# Altium schematic sheet Top.SchDoc(Top)
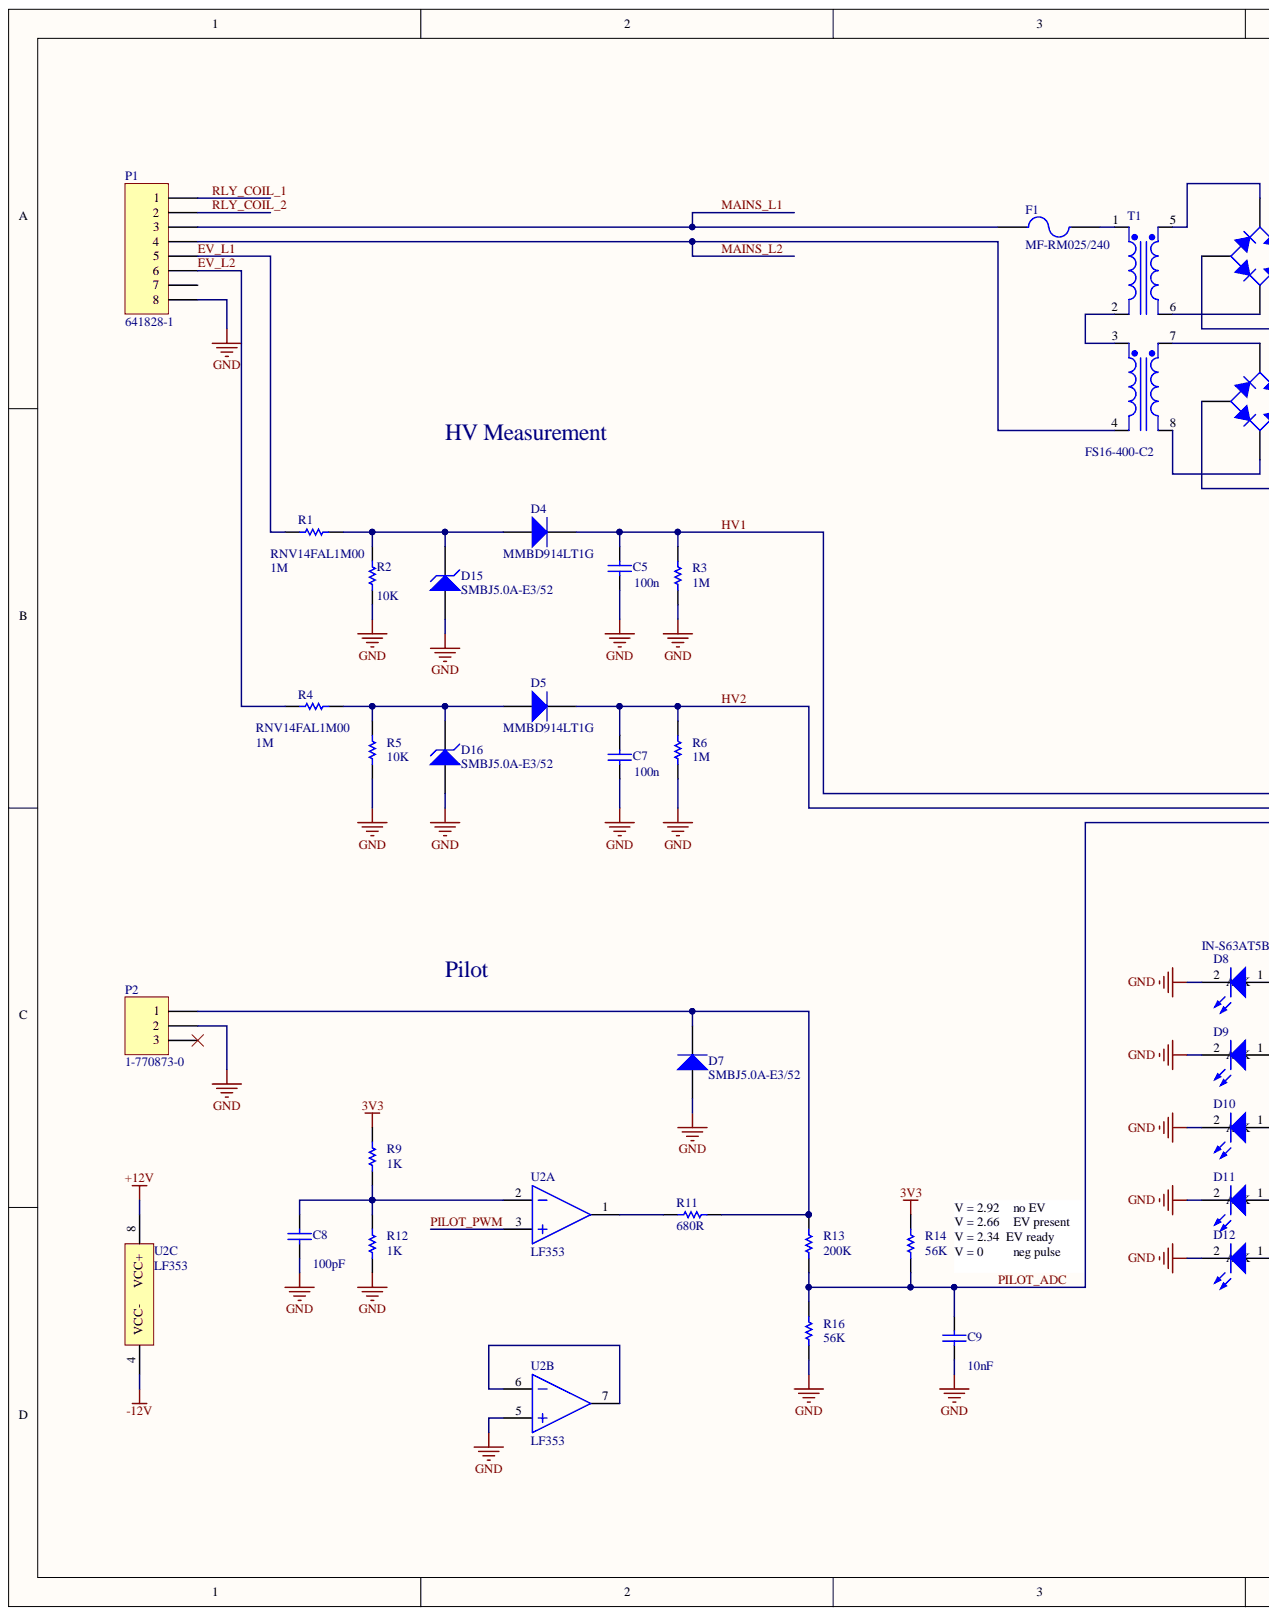
[diagram p0: Top.SchDoc, left]
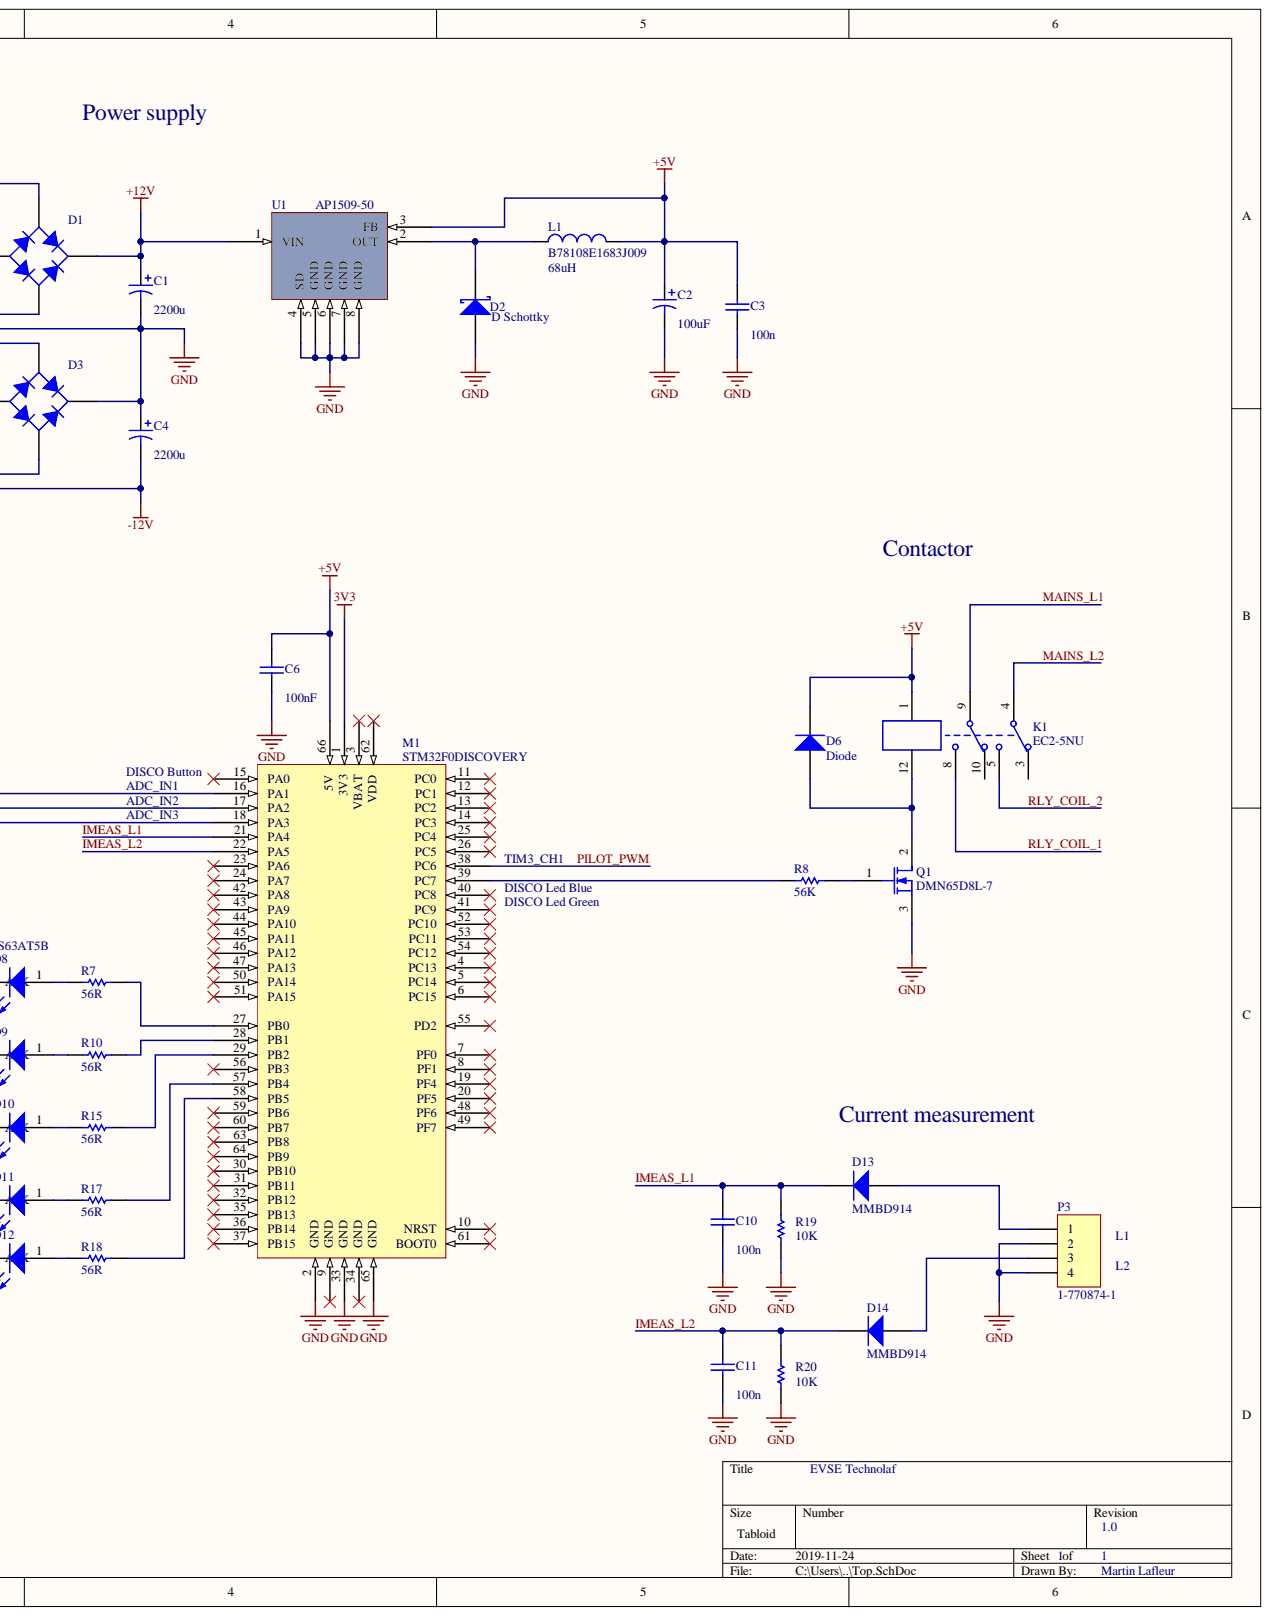
[diagram p1: Top.SchDoc, right]

## Parts
C1  value 2200u  [RB7.6-15]  (hidden comment: Cap Pol1)
C2  value 100uF  [RB0.1]  (hidden comment: Cap Pol1)
C3  value 100n  [6-0805_M]  (hidden comment: Cap)
C4  2200u  [RB7.6-15]
C5  value 100n  [6-0805_M]  (hidden comment: Cap)
C6  value 100nF  [6-0805_M]  (hidden comment: Cap)
C7  value 100n  [6-0805_M]  (hidden comment: Cap)
C8  value 100pF  [6-0805_M]  (hidden comment: Cap)
C9  value 10nF  [6-0805_M]  (hidden comment: Cap)
C10  value 100n  [6-0805_M]  (hidden comment: Cap)
C11  value 100n  [6-0805_M]  (hidden comment: Cap)
D1  Bridge1  [KBP06M]  (value not drawn)
D2  D Schottky  [AXIAL-0.6]
D3  Bridge1  [KBP06M]  (value not drawn)
D4  MMBD914LT1G  [SOT23_914]
D5  MMBD914LT1G  [SOT23_914]
D6  Diode  [SOT23_914]
D7  SMBJ5.0A-E3/52  [SMB]
D8  IN-S63AT5B  [LED_T13/4]
D9  (no value)  [LED_T13/4]
D10  (no value)  [LED_T13/4]
D11  (no value)  [LED_T13/4]
D12  (no value)  [LED_T13/4]
D13  MMBD914  [SOT23_914]
D14  MMBD914  [SOT23_914]
D15  SMBJ5.0A-E3/52  [SMB]
D16  SMBJ5.0A-E3/52  [SMB]
F1  MF-RM025/240  [MF-RM025/240]
K1  EC2-5NU  [EC2-5NU]
L1  B78108E1683J009  value 68uH  [AXIAL-0.6]
M1  STM32F0DISCOVERY  [STM32F0DISCO]
P1  641828-1  [641828-1]
P2  1-770873-0  [MATENLOK_1X3]
P3  1-770874-1  [MATENLOK_2X2]
Q1  DMN65D8L-7  [SOT23_914]
R1  RNV14FAL1M00  value 1M  [AXIAL-0.6]
R2  value 10K  [6-0805_M]  (hidden comment: Res1)
R3  value 1M  [6-0805_M]  (hidden comment: Res1)
R4  RNV14FAL1M00  value 1M  [AXIAL-0.6]
R5  value 10K  [6-0805_M]  (hidden comment: Res1)
R6  value 1M  [6-0805_M]  (hidden comment: Res1)
R7  value 56R  [6-0805_M]  (hidden comment: Res1)
R8  value 56K  [6-0805_M]  (hidden comment: Res1)
R9  value 1K  [6-0805_M]  (hidden comment: Res1)
R10  value 56R  [6-0805_M]  (hidden comment: Res1)
R11  value 680R  [6-0805_M]  (hidden comment: Res1)
R12  value 1K  [6-0805_M]  (hidden comment: Res1)
R13  value 200K  [6-0805_M]  (hidden comment: Res1)
R14  value 56K  [6-0805_M]  (hidden comment: Res1)
R15  value 56R  [6-0805_M]  (hidden comment: Res1)
R16  value 56K  [6-0805_M]  (hidden comment: Res1)
R17  value 56R  [6-0805_M]  (hidden comment: Res1)
R18  value 56R  [6-0805_M]  (hidden comment: Res1)
R19  value 10K  [6-0805_M]  (hidden comment: Res1)
R20  value 10K  [6-0805_M]  (hidden comment: Res1)
T1  FS16-400-C2  [FS16-400-C2]
U1  AP1509-50  [SO8_N]
U2  LF353  [SO8_N]  (parts A,B,C)

## Nets
3V3: M1.1(3V3) R9.2 R14.2
+5V: C2.1 C3.2 C6.2 D6.2 K1.1 L1.2 M1.66(5V) U1.3(FB)
+12V: C1.1 D1.1 U1.1(VIN) U2.8(VCC+)
-12V: C4.2 D3.3 U2.4(VCC-)
GND: C1.2 C2.2 C3.1 C4.1 C5.1 C6.1 C7.1 C8.1 C9.1 C10.1 C11.1 D1.3 D2.1 D3.1 D7.1 D8.2 D9.2 D10.2 D11.2 D12.2 D15.1 D16.1 M1.2(GND) M1.33(GND) M1.65(GND) P1.8 P2.2 P3.2 P3.4 Q1.3 R2.1 R3.1 R5.1 R6.1 R12.1 R16.1 R19.1 R20.1 U1.4(SD) U1.5(GND) U1.6(GND) U1.7(GND) U1.8(GND) U2.5
EV_L1: P1.5 R1.2
EV_L2: P1.6 R4.2
HV1: C5.2 D4.2 M1.16(PA1) R3.2
HV2: C7.2 D5.2 M1.17(PA2) R6.2
IMEAS_L1: C10.2 D13.2 M1.21(PA4) R19.2
IMEAS_L2: C11.2 D14.2 M1.22(PA5) R20.2
MAINS_L1: F1.1 K1.9 P1.3
MAINS_L2: K1.4 P1.4 T1.4
PILOT_ADC: C9.2 M1.18(PA3) R13.1 R14.1 R16.2
PILOT_PWM: M1.38(PC6) U2.3
RLY_COIL_1: K1.8 P1.1
RLY_COIL_2: K1.5 P1.2
NetC8_2: C8.2 R9.1 R12.2 U2.2
NetD1_2: D1.2 T1.6
NetD1_4: D1.4 T1.5
NetD2_2: D2.2 L1.1 U1.2(OUT)
NetD3_2: D3.2 T1.8
NetD3_4: D3.4 T1.7
NetD4_1: D4.1 D15.2 R1.1 R2.2
NetD5_1: D5.1 D16.2 R4.1 R5.2
NetD6_1: D6.1 K1.12 Q1.2
NetD7_2: D7.2 P2.1 R11.1 R13.2
NetD8_1: D8.1 R7.2
NetD9_1: D9.1 R10.2
NetD10_1: D10.1 R15.2
NetD11_1: D11.1 R17.2
NetD12_1: D12.1 R18.2
NetD13_1: D13.1 P3.1
NetD14_1: D14.1 P3.3
NetF1_2: F1.2 T1.1
NetM1_27: M1.27(PB0) R7.1
NetM1_28: M1.28(PB1) R10.1
NetM1_29: M1.29(PB2) R15.1
NetM1_39: M1.39(PC7) R8.2
NetM1_57: M1.57(PB4) R17.1
NetM1_58: M1.58(PB5) R18.1
NetQ1_1: Q1.1 R8.1
NetR11_2: R11.2 U2.1
NetT1_2: T1.2 T1.3
NetU2_6: U2.6 U2.7

Not connected: K1 3 10; M1 3(VBAT) 4(PC13) 5(PC14) 6(PC15) 7(PF0) 8(PF1) 9(GND) 10(NRST) 11(PC0) 12(PC1) 13(PC2) 14(PC3) 15(PA0) 19(PF4) 20(PF5) 23(PA6) 24(PA7) 25(PC4) 26(PC5) 30(PB10) 31(PB11) 32(PB12) 34(GND) 35(PB13) 36(PB14) 37(PB15) 40(PC8) 41(PC9) 42(PA8) 43(PA9) 44(PA10) 45(PA11) 46(PA12) 47(PA13) 48(PF6) 49(PF7) 50(PA14) 51(PA15) 52(PC10) 53(PC11) 54(PC12) 55(PD2) 56(PB3) 59(PB6) 60(PB7) 61(BOOT0) 62(VDD) 63(PB8) 64(PB9); P1 7; P2 3

## Library data of the parts
C1 C2 C4 = Cap Pol1: Polarized Capacitor (Radial); Signal Integrity: Cap Pol; Published: 8-Jun-2000; PackageReference: RB7.6-15; PackageDescription: Polarized Capacitor (Radial); 2 Leads; Library Name: Miscellaneous Devices.IntLib; LatestRevisionNote: Re-released for DXP Platform.; LatestRevisionDate: 17-Jul-2002; Component Kind: Standard; Publisher: Altium Limited
  C2: Simulation: CAP
  C4: Value: 2200u; Simulation: CAP
C3 C5-C11 = Cap: Capacitor; Published: 8-Jun-2000; PackageReference: RAD-0.3; PackageDescription: Radial Cap, Thru-Hole; 2 Leads; 0.3 in Pin Spacing; Library Name: Miscellaneous Devices.IntLib; LatestRevisionNote: Re-released for DXP Platform.; LatestRevisionDate: 17-Jul-2002; Component Kind: Standard; Publisher: Altium Limited
  C5-C11: Simulation: CAP; Signal Integrity: Cap
D1 D3 = Bridge1: Full Wave Diode Bridge; Published: 8-Jun-2000; PackageReference: D-38; PackageDescription: Bridge Rectifier; 4 Terminals; Body 9.4 x 9.4 mm (typ); Pitch 5.08 mm; Library Name: Miscellaneous Devices.IntLib; LatestRevisionNote: Sch pins A & K swapped.; LatestRevisionDate: 29-May-2009; DatasheetVersion: E2732B; Component Kind: Standard; Publisher: Altium Limited
D2 = D Schottky: Schottky Diode; Simulation: SKYDIODE; Signal Integrity: D Schottky; Published: 8-Jun-2000; PackageReference: SMB; PackageDescription: DO-214-AA/SMB; 2 C-Bend Leads; Body 5.3 x 3.6 mm, inc. leads (LxW); Library Name: Miscellaneous Devices.IntLib; LatestRevisionNote: Re-released for DXP Platform.; LatestRevisionDate: 17-Jul-2002; Component Kind: Standard; Code_JEDEC: DO-214-AA; Publisher: Altium Limited
D4-D7 D13 D14 = Diode: Default Diode; Published: 8-Jun-2000; PackageVersion: Sep-1996; PackageReference: SMC; PackageDescription: DO-214-AB/SMC; 2 C-Bend Leads; Body 7.9 x 5.9 mm, inc. leads (LxW); Library Name: Miscellaneous Devices.IntLib; LatestRevisionNote: Re-released for DXP Platform.; LatestRevisionDate: 17-Jul-2002; Component Kind: Standard; Code_JEDEC: DO-214-AB; Publisher: Altium Limited
  D4 D5 D13 D14: Simulation: DIODE; Signal Integrity: Diode
D8-D12 = LED0: Typical INFRARED GaAs LED; Published: 8-Jun-2000; PackageReference: LED-0; PackageDescription: LED; 2 Leads; Library Name: Miscellaneous Devices.IntLib; LatestRevisionNote: Mech Layer 1 removed.; LatestRevisionDate: 08-Jul-2005; Component Kind: Standard; Publisher: Altium Limited
D15 D16 = D Zener: Zener Diode; Published: 8-Jun-2000; PackageReference: DIODE-0.7; PackageDescription: Diode, Thru-Hole; 2 Leads; 0.7 in Pin Spacing; Library Name: Miscellaneous Devices.IntLib; LatestRevisionNote: Re-released for DXP Platform.; LatestRevisionDate: 17-Jul-2002; Component Kind: Standard; Publisher: Altium Limited
F1 = Fuse 2: MF-RM025/240-2; Published: 8-Jun-2000; PackageReference: PIN-W2/E2.8; PackageDescription: Thru-Hole; 2 Leads; Library Name: Miscellaneous Devices.IntLib; LatestRevisionNote: Re-released for DXP Platform.; LatestRevisionDate: 17-Jul-2002; Component Kind: Standard; Publisher: Altium Limited
K1 = Relay-DPDT: Dual-Pole Dual-Throw Relay; Published: 24-Mar-1999; PackageReference: DIP-P8; PackageDescription: Relay; 8 Leads; LatestRevisionNote: Re-released for DXP Platform.; LatestRevisionDate: 17-Jul-2002; Component Kind: Standard; Publisher: Altium Limited; Library Name: laf.SchLib
L1 = Inductor: Code_IEC: 1005; Published: 8-Jun-2000; PackageReference: 0402-A; PackageDescription: Chip Inductor; Library Name: Miscellaneous Devices.IntLib; LatestRevisionNote: IPC-7351 Footprint Added.; LatestRevisionDate: 29-May-2009; Description: Inductor; Component Kind: Standard; Code_JEITA: 0402; Publisher: Altium Limited
P1 = Header 8: Header, 8-Pin; Signal Integrity: Connector; Library Name: Miscellaneous Connectors.IntLib; LatestRevisionNote: Re-released for DXP Platform.; LatestRevisionDate: 17-Jul-2002; Component Kind: Standard; Publisher: Altium Limited
P2 = Header 3: Header, 3-Pin; Library Name: Miscellaneous Connectors.IntLib; LatestRevisionNote: Re-released for DXP Platform.; LatestRevisionDate: 17-Jul-2002; Component Kind: Standard; Publisher: Altium Limited
P3 = Header 4: Header, 4-Pin; Library Name: Miscellaneous Connectors.IntLib; LatestRevisionNote: Re-released for DXP Platform.; LatestRevisionDate: 17-Jul-2002; Component Kind: Standard; Publisher: Altium Limited
Q1 = MOSFET-N: N-Channel MOSFET; Simulation: NMOS; Published: 8-Jun-2000; PackageVersion: 1995/1996; PackageReference: E3; PackageDescription: TO, Flat Index; 3 In-Line, Axial Leads; Body Dia. 4.6mm; Lead Dia. 0.5 mm (max); LatestRevisionNote: Re-released for DXP Platform.; LatestRevisionDate: 17-Jul-2002; Component Kind: Standard; Publisher: Altium Limited; Library Name: laf.SchLib
R1-R20 = Res1: Resistor; Published: 8-Jun-2000; PackageReference: AXIAL-0.3; PackageDescription: Axial Device, Thru-Hole; 2 Leads; 0.3 in Pin Spacing; Library Name: Miscellaneous Devices.IntLib; LatestRevisionNote: Re-released for DXP Platform.; LatestRevisionDate: 17-Jul-2002; Component Kind: Standard; Publisher: Altium Limited
  R1 R3-R20: Simulation: RESISTOR; Signal Integrity: Res
T1 = TRANSFO_2p2s: Component Kind: Standard; Library Name: laf.SchLib
U1 = AP1509: Component Kind: Standard; Library Name: laf.SchLib
U2 = LM358P: IC OPAMP GP 700KHZ 8DIP; Manufacturer: Texas Instruments; Voltage - Supply, Single/Dual (±): 3 V ~ 32 V, ±1.5 V ~ 16 V; Voltage - Input Offset: 3mV; Slew Rate: 0.3 V/µs; Number of Circuits: 2; Emplacement: OP AMP TUBE; Current - Supply: 1mA; Current - Output / Channel: 30mA; Current - Input Bias: 20nA; Amplifier Type: General Purpose; Supplier Part Number 1: 296-1395-5-ND; Supplier Device Package: 8-PDIP; Supplier 1: Digi-Key; Stock 1: 12094; RoHS: RoHS Compliant; Pricing 1: 1=0.58, 10=0.45, 25=0.3788, 100=0.3085, 250=0.25568, 500=0.21156, 750=0.17631, 1000=0.15867 (CAD); Packaging: Tube; Package / Case: 8-DIP (0.300", 7.62mm); Output Type: -; Operating Temperature: 0°C ~ 70°C; Mounting Type: Through Hole; Manufacturer Part Number: LM358P; Gain Bandwidth Product: 700kHz; ComponentLink1URL: http://www.ti.com/lit/ds/symlink/lm358.pdf; ComponentLink1Description: http://www.ti.com/lit/ds/symlink/lm358.pdf; Component Kind: Standard; Category: Integrated Circuits (ICs); -3db Bandwidth: -; Library Name: GRR_IC.SchLib
M1 = STM32F0DISCOVERY: Component Kind: Standard; Library Name: laf.SchLib
